# Altium schematic sheet 09-Power.SchDoc
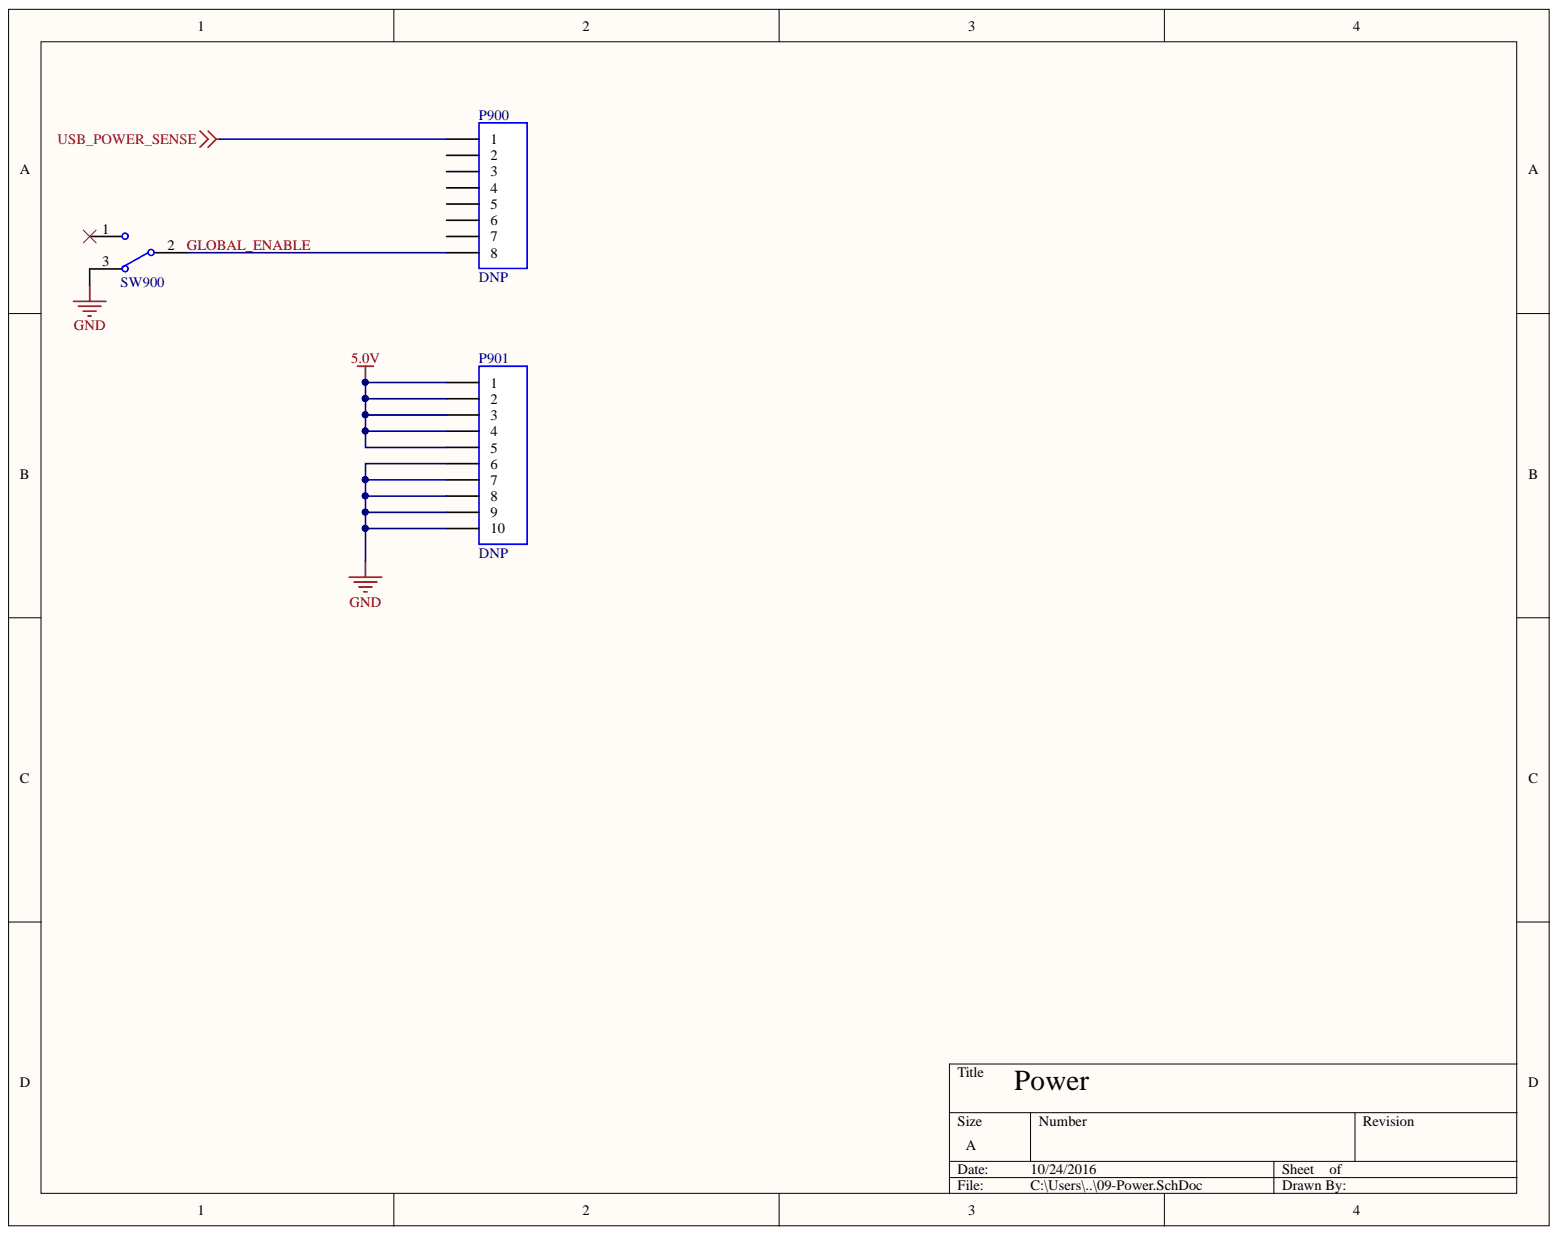
[diagram p0: 09-Power.SchDoc]

## Parts
P900  Usage: DNP  [HDR1X8]  (hidden comment: Header 8)
P901  Usage: DNP  [HDR1X10]  (hidden comment: Header 10)
SW900  SWITCH-SPDT  [SWITCH_OS102011MS2QN1]  (value not drawn)

## Nets
5.0V: P901.1 P901.2 P901.3 P901.4 P901.5
GND: P901.6 P901.7 P901.8 P901.9 P901.10 SW900.3
USB_POWER_SENSE: P900.1
GLOBAL_ENABLE: P900.8 SW900.2

Not connected: P900 2 3 4 5 6 7; SW900 1

## Library data of the parts
P900 = Header 8: Header, 8-Pin; Manufacturer: Sullins Connector Solutions; Manufacturer Part Number: PPTC081LFBN-RC; Number of Positions: 8; Number of Positions Loaded: All; Pitch: 0.100" (2.54mm); Category: Connectors, Interconnects; Color: Black; Component Kind: Standard; Connector Type: Header; Contact Finish: Tin; Contact Finish Thickness: -; Contact Type: Female Socket; Fastening Type: -; Features: -; LatestRevisionDate: 17-Jul-2002; LatestRevisionNote: Re-released for DXP Platform.; Library Name: ece353-2016.SchLib; Mounting Type: Through Hole; Number of Rows: 1; Packaging: Bulk; RoHS: RoHS Compliant; Row Spacing: -; Supplier: Digi-Key; Supplier 1: Digi-Key; Supplier Part Number: S7006-ND; Supplier Part Number 1: S7006-ND; Termination: Solder; Publisher: Altium Limited
P901 = Header 10: Header, 10-Pin; Manufacturer: Sullins Connector Solutions; Manufacturer Part Number: PPTC101LFBN-RC; Number of Positions: 10; Number of Positions Loaded: All; Pitch: 0.100" (2.54mm); Category: Connectors, Interconnects; Color: Black; Component Kind: Standard; Connector Type: Header; Contact Finish: Tin; Contact Finish Thickness: -; Contact Type: Female Socket; Fastening Type: -; Features: -; LatestRevisionDate: 17-Jul-2002; LatestRevisionNote: Re-released for DXP Platform.; Library Name: ece353-2016.SchLib; Mounting Type: Through Hole; Number of Rows: 1; Packaging: Bulk; RoHS: RoHS Compliant; Row Spacing: -; Supplier: Digi-Key; Supplier 1: Digi-Key; Supplier Part Number: S7008-ND; Supplier Part Number 1: S7008-ND; Termination: Solder; Publisher: Altium Limited
SW900 = SWITCH-SPDT: SPDT Subminiature Toggle Switch, Right Angle Mounting, Vertical Actuation; Manufacturer: C&K Components; Manufacturer Part Number: OS102011MS2QN1; Actuator Length: 4.00mm; Actuator Material: Polyoxymethylene (Acetal) (POM); Actuator Type: Standard; Contact Timing: Non-Shorting (BBM); Current Rating: 100mA (DC); Electrical Life: 10,000 Cycles; Switch Function: On-On; Switch Travel: 2.00mm; Voltage Rating - DC: 12V; Category: Switches; Circuit: SPDT; Component Kind: Standard; Contact Finish: Silver; Contact Material: Copper Alloy; Features: Epoxy Sealed Terminals; Library Name: ece353-2016.SchLib; Mechanical Life: 10,000 Cycles; Mounting Type: Through Hole; Operating Force: -; Operating Temperature: -30°C ~ 70°C; Packaging: Bulk; RoHS: RoHS Compliant; Supplier: Digi-Key; Supplier 1: Digi-Key; Supplier Part Number: CKN9565-ND; Supplier Part Number 1: CKN9565-ND; Termination Style: PC Pin; Voltage Rating - AC: -
